# Altium schematic sheet MINIECO-ECO-MICRO.SchDoc(MINIECO-ECO-MICRO)
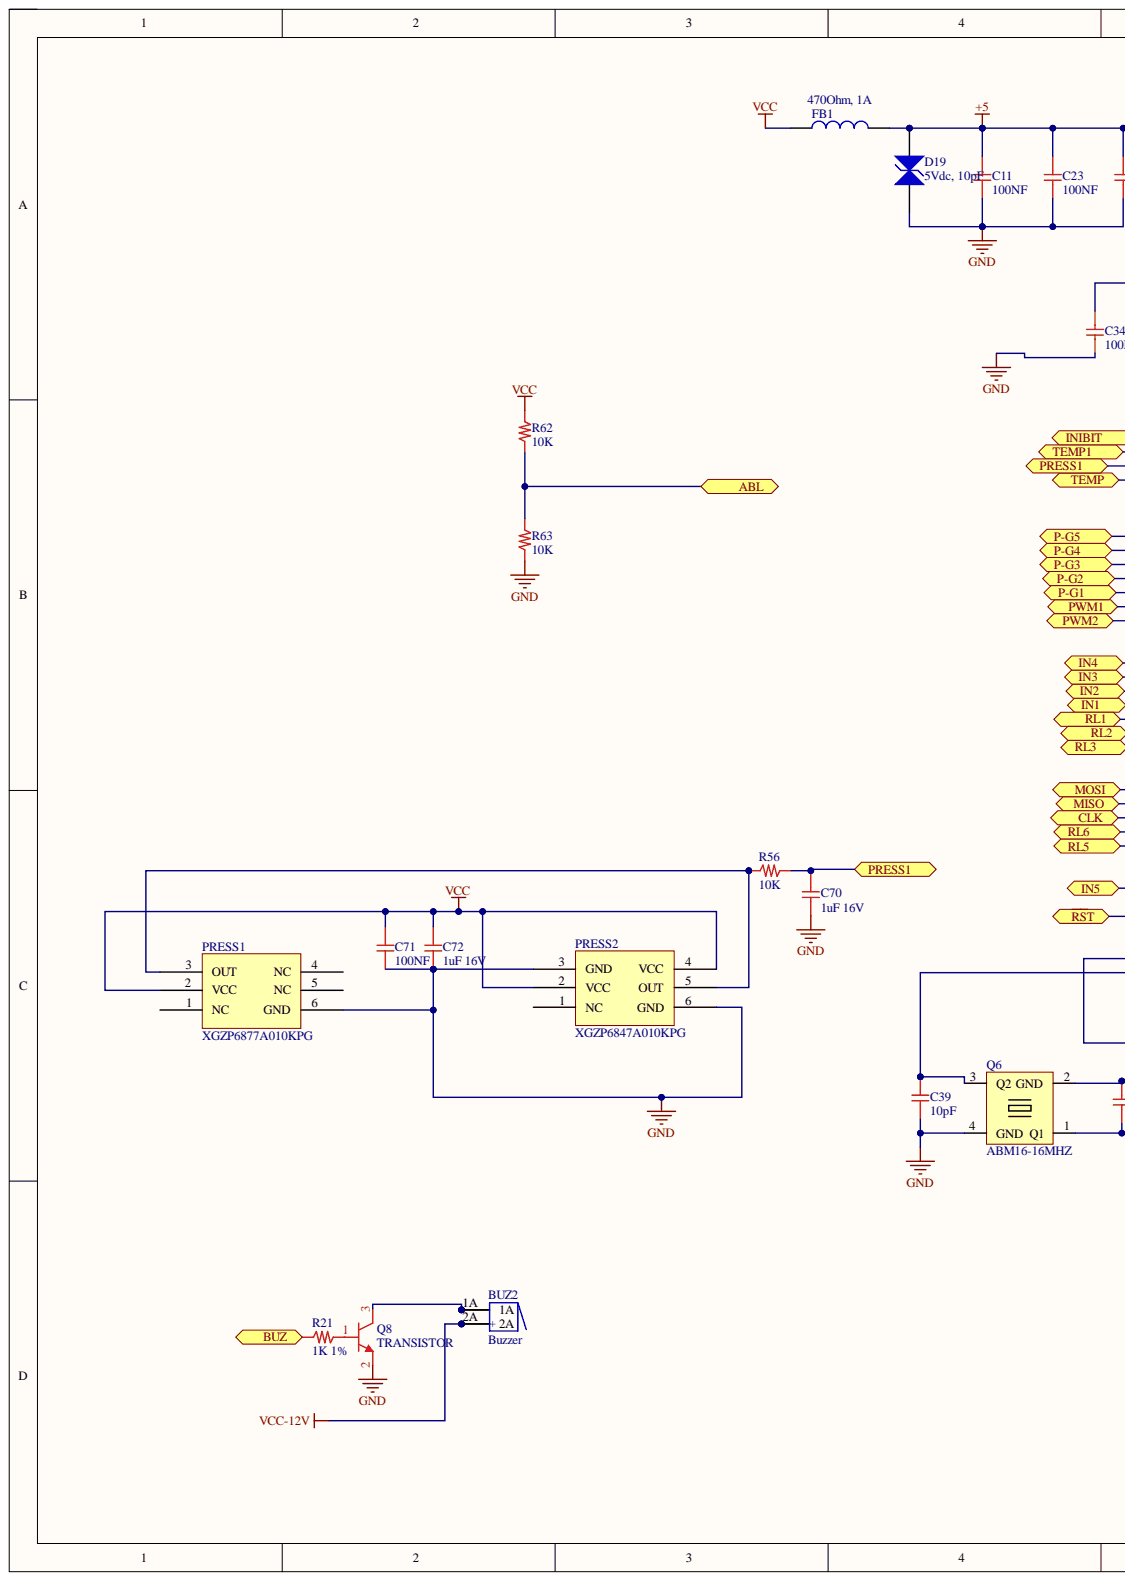
[diagram p0: MINIECO-ECO-MICRO.SchDoc, left]
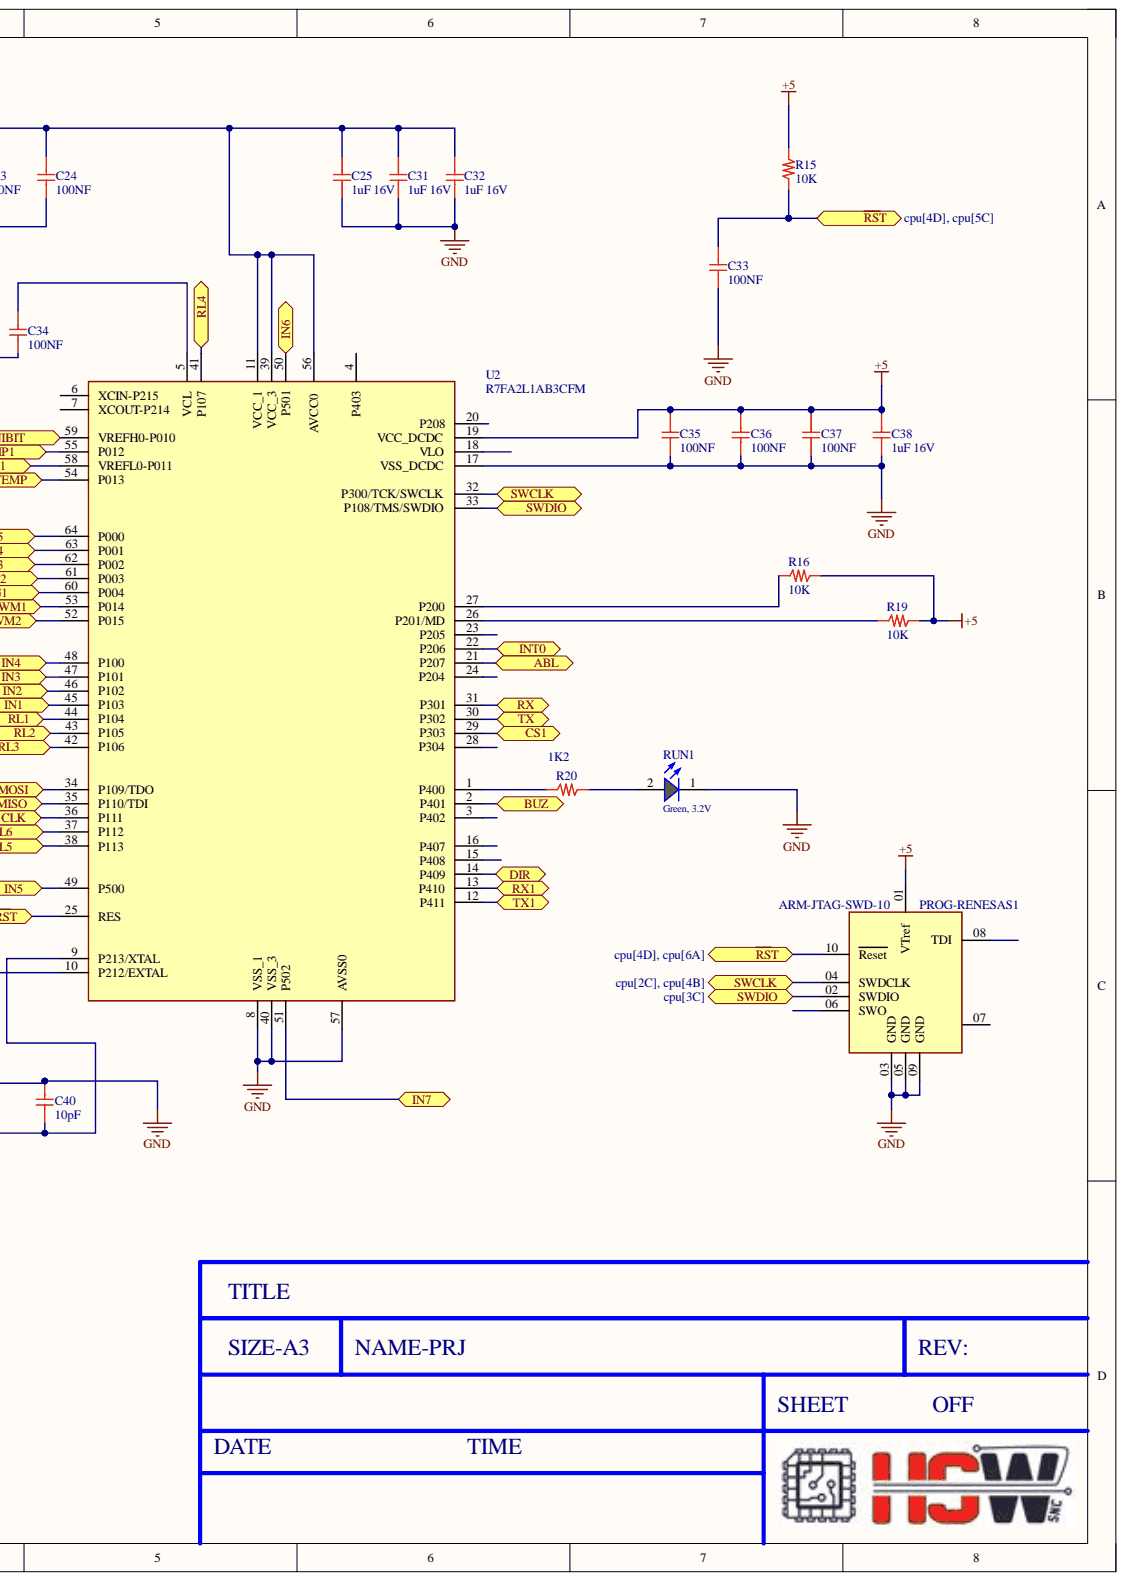
[diagram p1: MINIECO-ECO-MICRO.SchDoc, right]

## Parts
BUZ2  Buzzer  [BUZZER-14mm]
C11  100NF  [0402_C]
C23  100NF  [0402_C]
C24  100NF  [0402_C]
C25  1uF 16V  [0402_C]
C31  1uF 16V  [0402_C]
C32  1uF 16V  [0402_C]
C33  100NF  [0402_C]
C34  100NF  [0402_C]
C35  100NF  [0402_C]
C36  100NF  [0402_C]
C37  100NF  [0402_C]
C38  1uF 16V  [0402_C]
C39  10pF  [0402_C]
C40  10pF  [0402_C]
C70  1uF 16V  [0402_C]
C71  100NF  [0402_C]
C72  1uF 16V  [0402_C]
D19  5Vdc, 10pF  [WE-VE_0603]
FB1  470Ohm, 1A  Rated Current: 1A  [WE-CBF_0603_High Current]
PRESS1  XGZP6877A010KPG  [PRESS-XGZP6877A]
PRESS2  XGZP6847A010KPG  [PRESS-XGZP6877A - PTH]
PROG-RENESAS1  ARM-JTAG-SWD-10  [SAMTEC_FTSH-105-XX-X-DV]
Q6  ABM16-16MHZ  [ABM8]
Q8  TRANSISTOR  [SOT23]
R15  10K  [0402_R]
R16  10K  [0402_R]
R19  10K  [0402_R]
R20  1K2  [0603_R]
R21  1K 1%  [0603_R]
R56  10K  [0402_R]
R62  10K  [0603_R]
R63  10K  [0603_R]
RUN1  Green, 3.2V  Forward Voltage typ.: 3.2V  [WL-SMCW_0603_H=0.7mm]
U2  R7FA2L1AB3CFM  [LQFP-64_10x10MM_P0.5]

## Ports
ABL, BUZ, CLK, CS1, DIR, IN1, IN2, IN3, IN4, IN5, IN6, IN7, INIBIT, INT0, MISO, MOSI, P-G1, P-G2, P-G3, P-G4, P-G5, PRESS1, PWM1, PWM2, RL1, RL2, RL3, RL4, RL5, RL6, RX, RX1, SWCLK, SWDIO, TEMP, TEMP1, TX, TX1, ~{RS}T

## Nets
+5: C11.1 C23.1 C24.1 C25.1 C31.1 C32.1 C35.1 C36.1 C37.1 C38.1 D19.2 FB1.2 PROG-RENESAS1.01(VTref) R15.1 R16.2 R19.2 U2.11(VCC_1) U2.19(VCC_DCDC) U2.39(VCC_3) U2.56(AVCC0)
GND: C11.2 C23.2 C24.2 C25.2 C31.2 C32.2 C33.2 C34.2 C35.2 C36.2 C37.2 C38.2 C39.2 C40.1 C70.1 C71.1 C72.1 D19.1 PRESS1.6(GND) PRESS2.3(GND) PRESS2.6(GND) PROG-RENESAS1.03(GND) PROG-RENESAS1.05(GND) PROG-RENESAS1.09(GND) Q6.2(GND) Q6.4(GND) Q8.2 R63.2 RUN1.1 U2.8(VSS_1) U2.17(VSS_DCDC) U2.40(VSS_3) U2.57(AVSS0)
VCC: C71.2 C72.2 FB1.1 PRESS1.2(VCC) PRESS2.2(VCC) PRESS2.4(VCC) R62.1
VCC-12V: BUZ2.2 BUZ2.2A
~{RS}T: C33.1 PROG-RENESAS1.10(Reset) R15.2 U2.25(RES) ; port ~{RS}T
ABL: R62.2 R63.1 U2.21(P207) ; port ABL
BUZ: R21.1 U2.2(P401) ; port BUZ
CLK: U2.36(P111) ; port CLK
CS1: U2.29(P303) ; port CS1
DIR: U2.14(P409) ; port DIR
IN1: U2.45(P103) ; port IN1
IN2: U2.46(P102) ; port IN2
IN3: U2.47(P101) ; port IN3
IN4: U2.48(P100) ; port IN4
IN5: U2.49(P500) ; port IN5
IN6: U2.50(P501) ; port IN6
IN7: U2.51(P502) ; port IN7
INIBIT: U2.59(VREFH0-P010) ; port INIBIT
INT0: U2.22(P206) ; port INT0
MISO: U2.35(P110/TDI) ; port MISO
MOSI: U2.34(P109/TDO) ; port MOSI
P-G1: U2.60(P004) ; port P-G1
P-G2: U2.61(P003) ; port P-G2
P-G3: U2.62(P002) ; port P-G3
P-G4: U2.63(P001) ; port P-G4
P-G5: U2.64(P000) ; port P-G5
PRESS1: C70.2 R56.2 U2.58(VREFL0-P011) ; port PRESS1
PWM1: U2.53(P014) ; port PWM1
PWM2: U2.52(P015) ; port PWM2
RL1: U2.44(P104) ; port RL1
RL2: U2.43(P105) ; port RL2
RL3: U2.42(P106) ; port RL3
RL4: U2.41(P107) ; port RL4
RL5: U2.38(P113) ; port RL5
RL6: U2.37(P112) ; port RL6
RX: U2.31(P301) ; port RX
RX1: U2.13(P410) ; port RX1
SWCLK: PROG-RENESAS1.04(SWDCLK) U2.32(P300/TCK/SWCLK) ; port SWCLK
SWDIO: PROG-RENESAS1.02(SWDIO) U2.33(P108/TMS/SWDIO) ; port SWDIO
TEMP: U2.54(P013) ; port TEMP
TEMP1: U2.55(P012) ; port TEMP1
TX: U2.30(P302) ; port TX
TX1: U2.12(P411) ; port TX1
NetBUZ2_1: BUZ2.1(1A) BUZ2.1A Q8.3
NetC34_1: C34.1 U2.5(VCL)
NetC39_1: C39.1 Q6.3(Q2) U2.10(P212/EXTAL)
NetC40_2: C40.2 Q6.1(Q1) U2.9(P213/XTAL)
NetPRESS1_3: PRESS1.3(OUT) PRESS2.5(OUT) R56.1
NetQ8_1: Q8.1 R21.2
NetR16_1: R16.1 U2.27(P200)
NetR19_1: R19.1 U2.26(P201/MD)
NetR20_1: R20.1 U2.1(P400)
NetR20_2: R20.2 RUN1.2

Not connected: PRESS1 1(NC) 4(NC) 5(NC); PRESS2 1(NC); PROG-RENESAS1 06(SWO) 07(NC) 08(TDI); U2 3(P402) 4(P403) 6(XCIN-P215) 7(XCOUT-P214) 15(P408) 16(P407) 18(VLO) 20(P208) 23(P205) 24(P204) 28(P304)

## Library data of the parts
BUZ2 = Buzzer: Magnetic Transducer Buzzer; Component Kind: Standard; ComponentLink1Description: Manufacturer Link; ComponentLink1URL: http://www.megastar.com/linecard/buzzmode/index.php; DatasheetVersion: 17-Dec-2003; LatestRevisionDate: 17-Dec-2003; LatestRevisionNote: Schematic revised, PCB Footprint replaced.; PackageDescription: Magnetic Transducer Buzzer; PackageReference: ABSM-1574; Published: 24-Mar-1999; Library Name: HSW.SchLib; Publisher: Altium Limited
C11 C23 C24 C33-C37 C71 = 100NF 16V: Cap X7R 100NF 16V; Cod. Interno: COCS556561600104; Cod. Produttore: ??; Component Kind: Standard; Library Name: CapSMD0402.SchLib; Produttore: ??
C25 C31 C32 C38 C70 C72 = 1uF 16V: Cap X7R 1uF 16V; Cod. Interno: COCS556561600104; Cod. Produttore: ??; Component Kind: Standard; Library Name: CapSMD0402.SchLib; Produttore: ??
C39 C40 = 10pF 50V: Cap COG 10pF 50V; Cod. Interno: COCS506565000100; Cod. Produttore: ??; Component Kind: Standard; Library Name: CapSMD0402.SchLib; Produttore: ??
D19 = 82356050100: WE-VE ESD Suppressor, size 0603, 5Vdc, 10pF; Clamp Voltage: 60V; ESD Voltage air discharge: 15kV; Leakage Current: 1uA; Capacitance: 10pF; Component Kind: Standard; ComponentLink1Description: Manufacturer; ComponentLink1URL: http://www.we-online.de; ComponentLink2Description: Datasheet; ComponentLink2URL: https://katalog.we-online.com/pbs/datasheet/82356050100.pdf; Library Name: WE-VE_0603.SchLib; Mounting Technology: SMT; Operating Temperature: -40 to +85degC; Packaging: Tape and Reel; Part Number: 82356050100; Published Date: June 2019; Size: 0603; Working Voltage: 5Vdc; Publisher: Wurth Elektronik
FB1 = 742792645: WE-CBF SMT EMI Suppression Ferrite Bead, size 0603, 470Ohm, 1A; DC Resistance max.: 0.2Ohm; Impedance max.: 600Ohm; Type: High Current; Component Kind: Standard; ComponentLink1Description: Manufacturer; ComponentLink1URL: http://www.we-online.de; ComponentLink2Description: Datasheet; ComponentLink2URL: https://katalog.we-online.com/pbs/datasheet/742792645.pdf; Impedance@100 MHz: 470Ohm; Library Name: WE-CBF_0603.SchLib; Mounting Technology: SMT; Operating Temperature: -55 to +125degC; Packaging: Tape and Reel; Part Number: 742792645; Published Date: March 2019; Size: 0603; Publisher: Wurth Elektronik
PRESS1 = PRESS-XGZP6877A010KPG -1BAR: XGZP6877A010KPG  PRESSURE SENSOR-1bar analogico; Component Kind: Standard; Library Name: HSW.SchLib
PRESS2 = PRESS-XGZP6847A010KPG-0,1BAR: XGZP6877A010KPG  PRESSURE SENSOR-0,1bar analogico; Component Kind: Standard; Library Name: HSW.SchLib
PROG-RENESAS1 = ARM-JTAG-SWD-10: Connector Header Surface Mount 10 position 0.050" (1.27mm) FTSH-105-XX-X-DV; MF: {'manufacturer': u'Samtec'}; Availability: Unavailable; Component Kind: Standard; MP: FTSH-105-XX-X-DV; Package: None; Price: None; Library Name: distelco.SchLib
Q6 = ABM16-16MHZ: QUARZO 16MHZ; Component Kind: Standard; Manufacturer Part Number: ABM16-16MHZ; Library Name: HSW.SchLib
Q8 = BC847B: NPN Silicon Darlington Transistors; Cod. Interno: TRXS ??; Cod. Produttore: BCV27; Ic: 500mA; Produttore: INFINEON; Vcb: 40V; Vce: 30V; Component Kind: Standard; Library Name: HSW.SchLib
R15 R16 R19 R56 = 10K: Res Film Spesso 1/16W 10K 5%; Cod. Interno: RESS4165560C0103; Cod. Produttore: ??; Component Kind: Standard; Library Name: ResSMD0402.SchLib; Produttore: ??
R20 = 1K2: Res Film Spesso 1/16W 1K2 5%; Cod. Produttore: ??; Component Kind: Standard; Library Name: ResSMD0603.SchLib; Produttore: ??
R21 = 1K 1%: Res Film Spesso 1/16W 1K 1%; Cod. Interno: RESS4166356B0102; Cod. Produttore: ??; Component Kind: Standard; Library Name: ResSMD0603.SchLib; Produttore: ??
R62 R63 = 10K: Res Film Spesso 1/16W 10K 5%; Cod. Interno: RESS4166556B0103??; Cod. Produttore: ??; Component Kind: Standard; Library Name: ResSMD0603.SchLib; Produttore: ??
RUN1 = 150060GS75000: WL-SMCW SMT Mono-color Chip LED Waterclear, size 0603, Green, 3.2V, 140deg; Chip Technology: InGaN; Dominant Wavelength typ.: 525nm; Luminous Intensity typ.: 430mcd; Peak Wavelength typ.: 515nm; Component Kind: Standard; ComponentLink1Description: Manufacturer; ComponentLink1URL: http://www.we-online.de; ComponentLink2Description: Datasheet; ComponentLink2URL: https://www.we-online.com/catalog/datasheet/150060GS75000.pdf; Emitting Color: Green; Library Name: WL-SMCW_0603.SchLib; Mounting Technology: SMT; Operating Temperature: -40 to +85degC; Packaging: Tape and Reel; Part Number: 150060GS75000; Published Date: December 2019; Size: 0603; Viewing Angle: 140deg; Publisher: Wurth Elektronik
U2 = R7FA2L1AB3CFM: Ultra low power 48 MHz Arm® Cortex®-M23 core, up to 256-KB code flash memory, 32 KB SRAM, Capacitive Sensing Unit  (CTSU2), 12-bit A/D Converter, 12-bit D/A Converter, Security and Safety features.; Manufacturer_Name: Renesas Electronics; Manufacturer_Part_Number: R7FA6E10D2CFM#AA0; Geometry.Height: 1.7mm; Component Kind: Standard; Datasheet Link: https://www.mouser.in/datasheet/2/698/REN_r01ds0365ej0110_ra6m4_DST_20200929-2930852.pdf; Library Name: MCU_Renesas.SchLib; Mouser Part Number: 968-R7FA6E10D2CFM#AA0; Mouser Price/Stock: https://www.mouser.co.uk/ProductDetail/Renesas-Electronics/R7FA6M4AE3CFPAA0?qs=DPoM0jnrROXI34Znh5Bqiw%3D%3D
